# Altium schematic sheet BQ107-A5.SchDoc
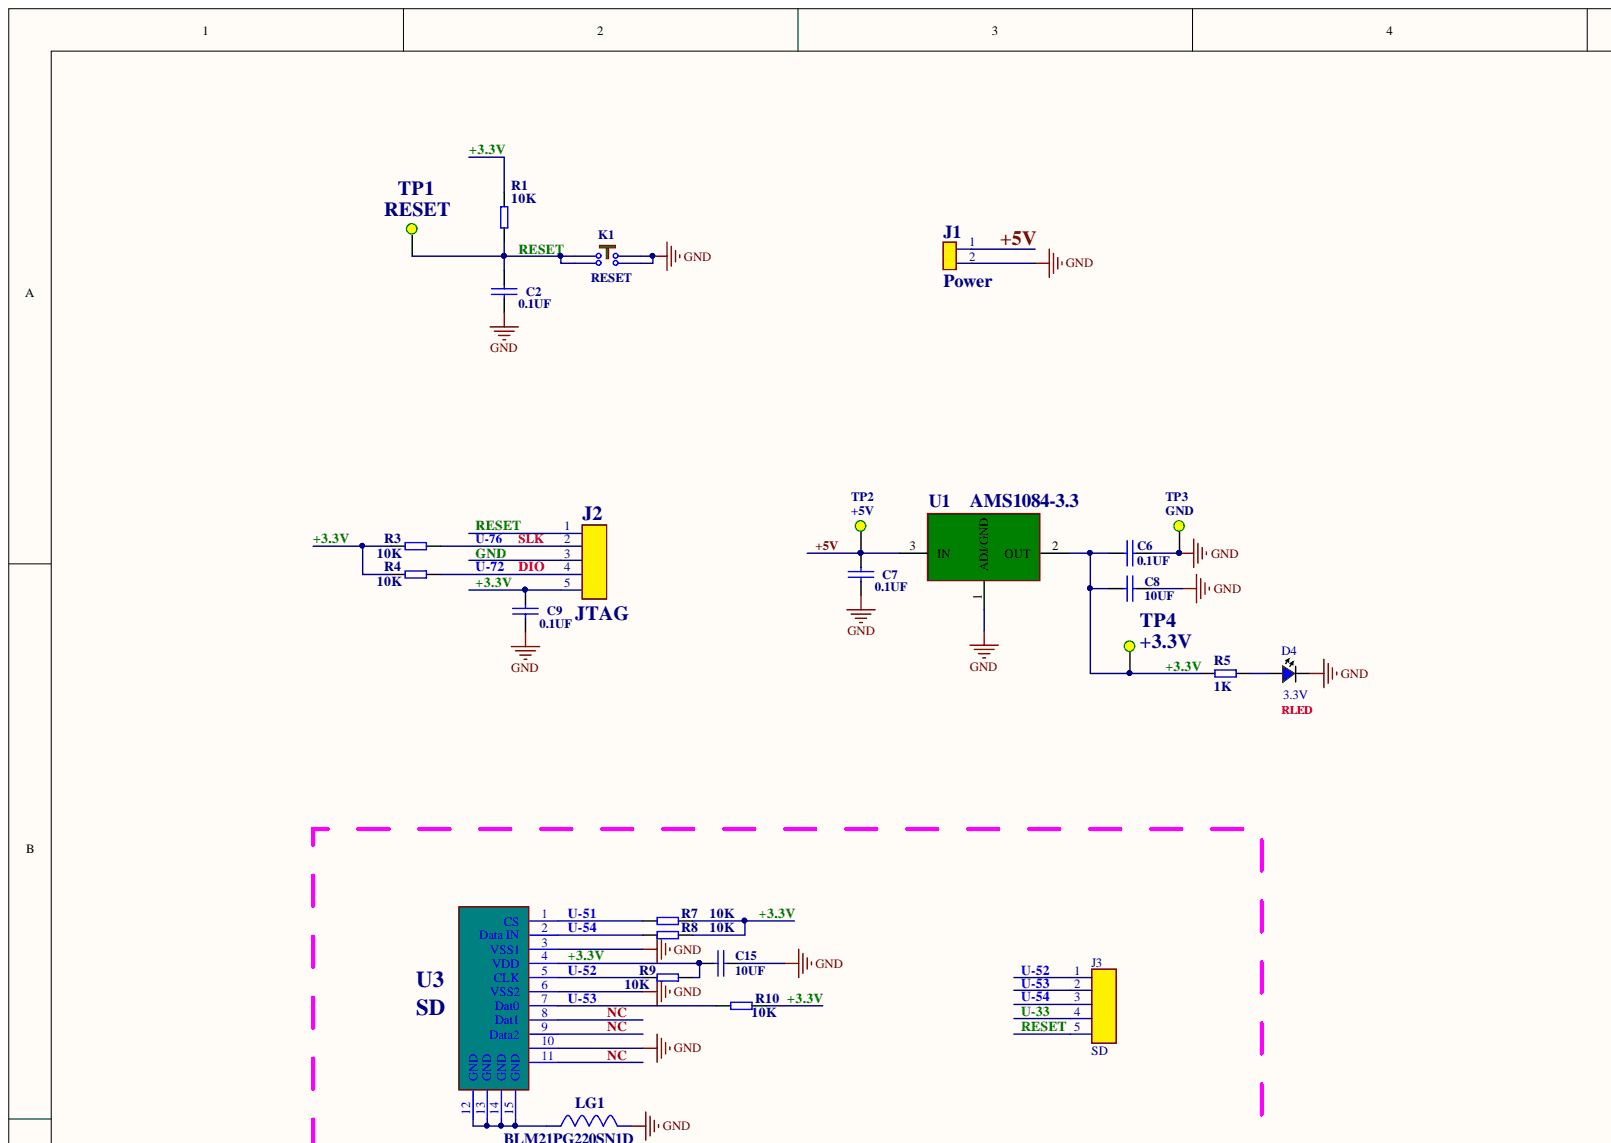
[diagram p0: BQ107-A5.SchDoc, top left]
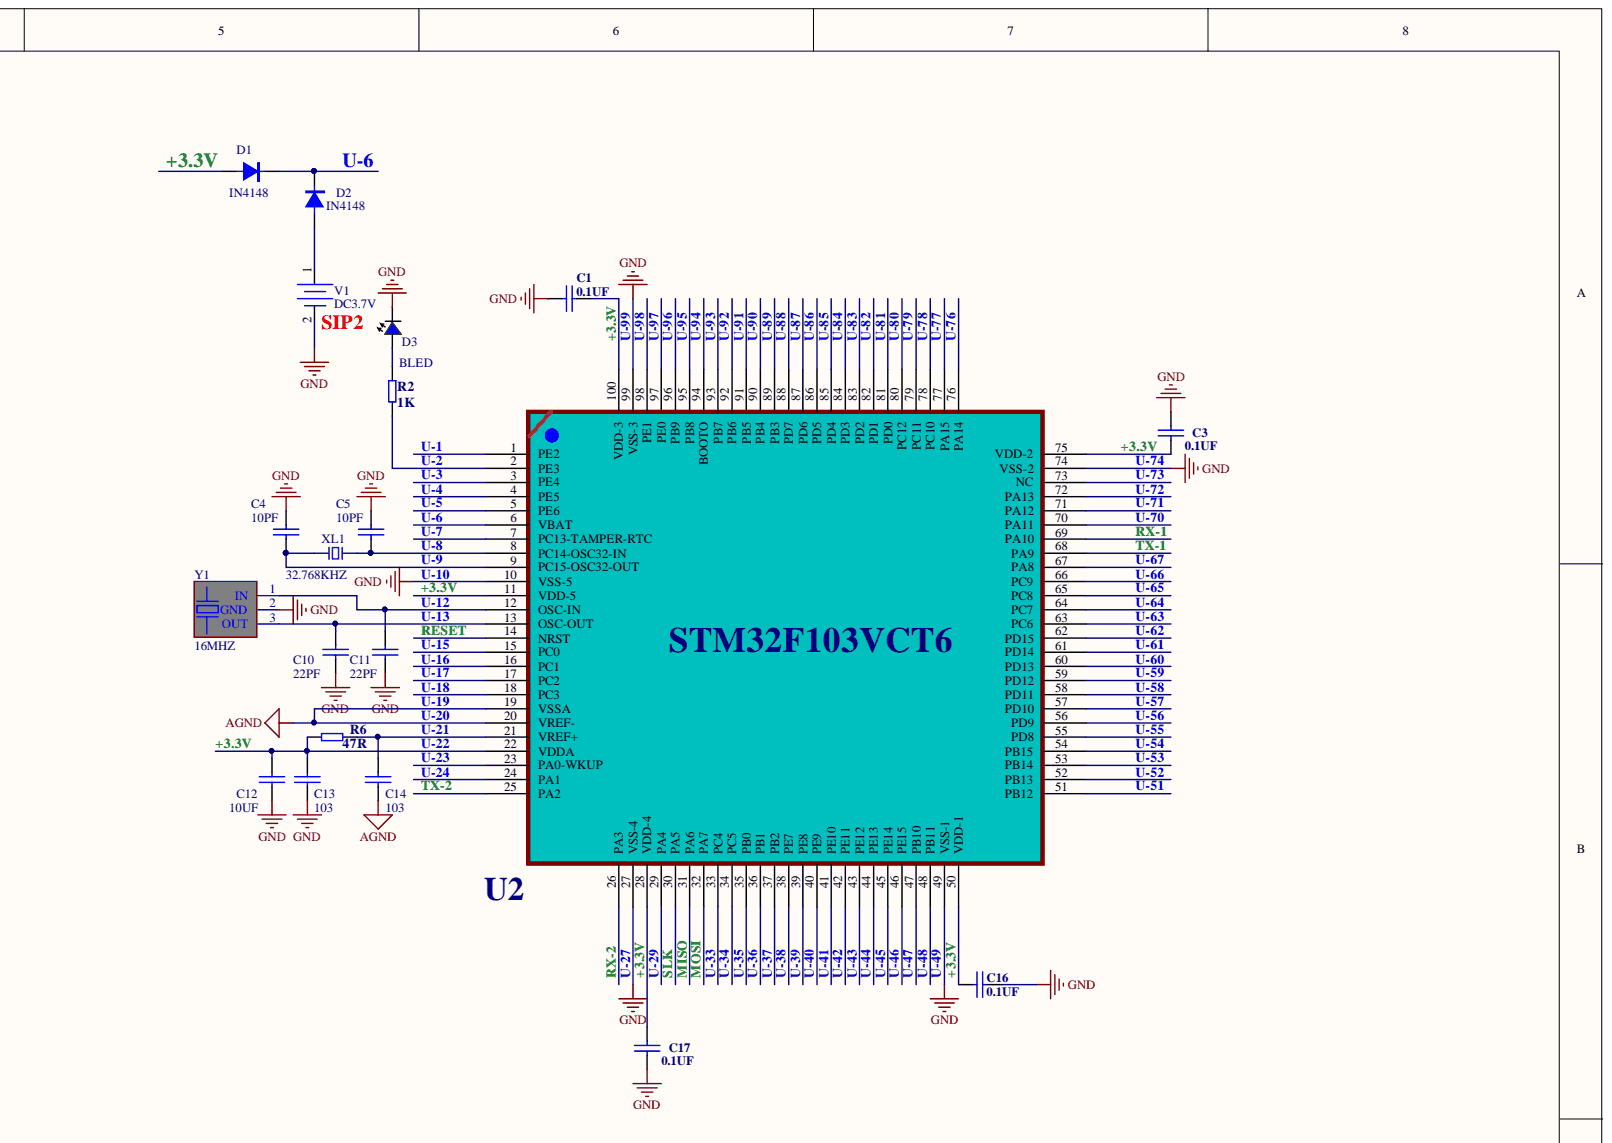
[diagram p1: BQ107-A5.SchDoc, top right]
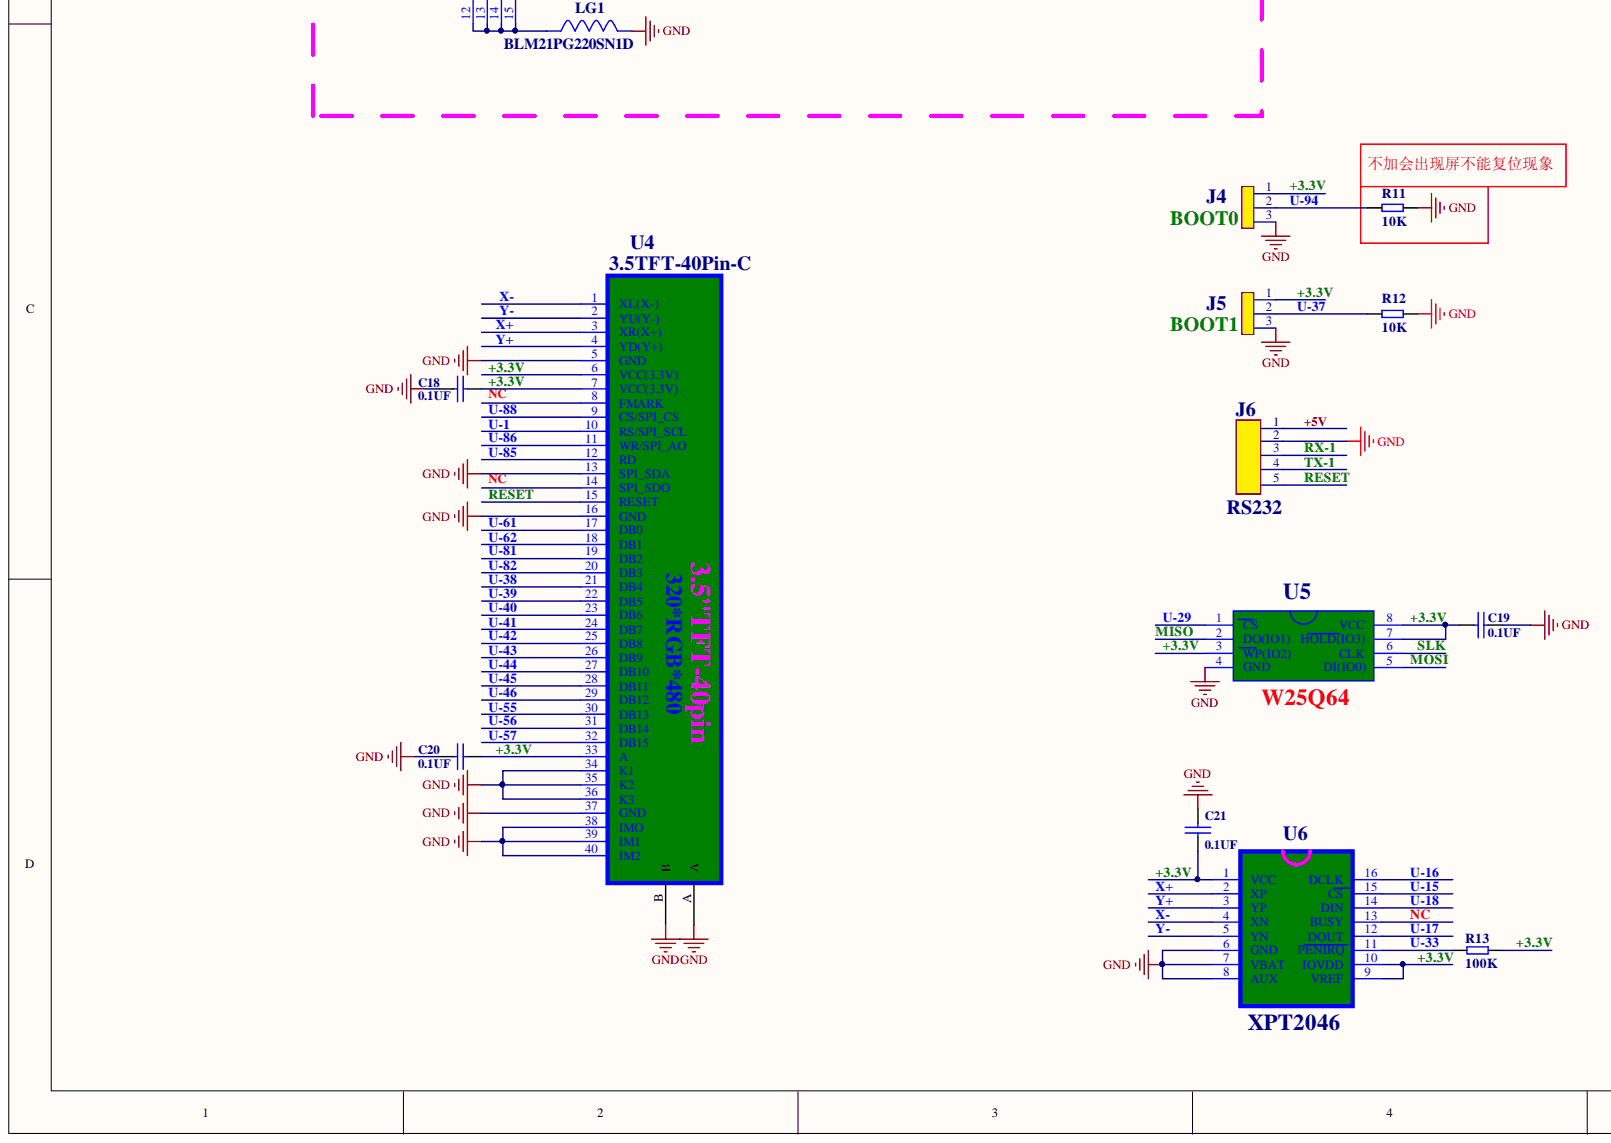
[diagram p2: BQ107-A5.SchDoc, bottom left]
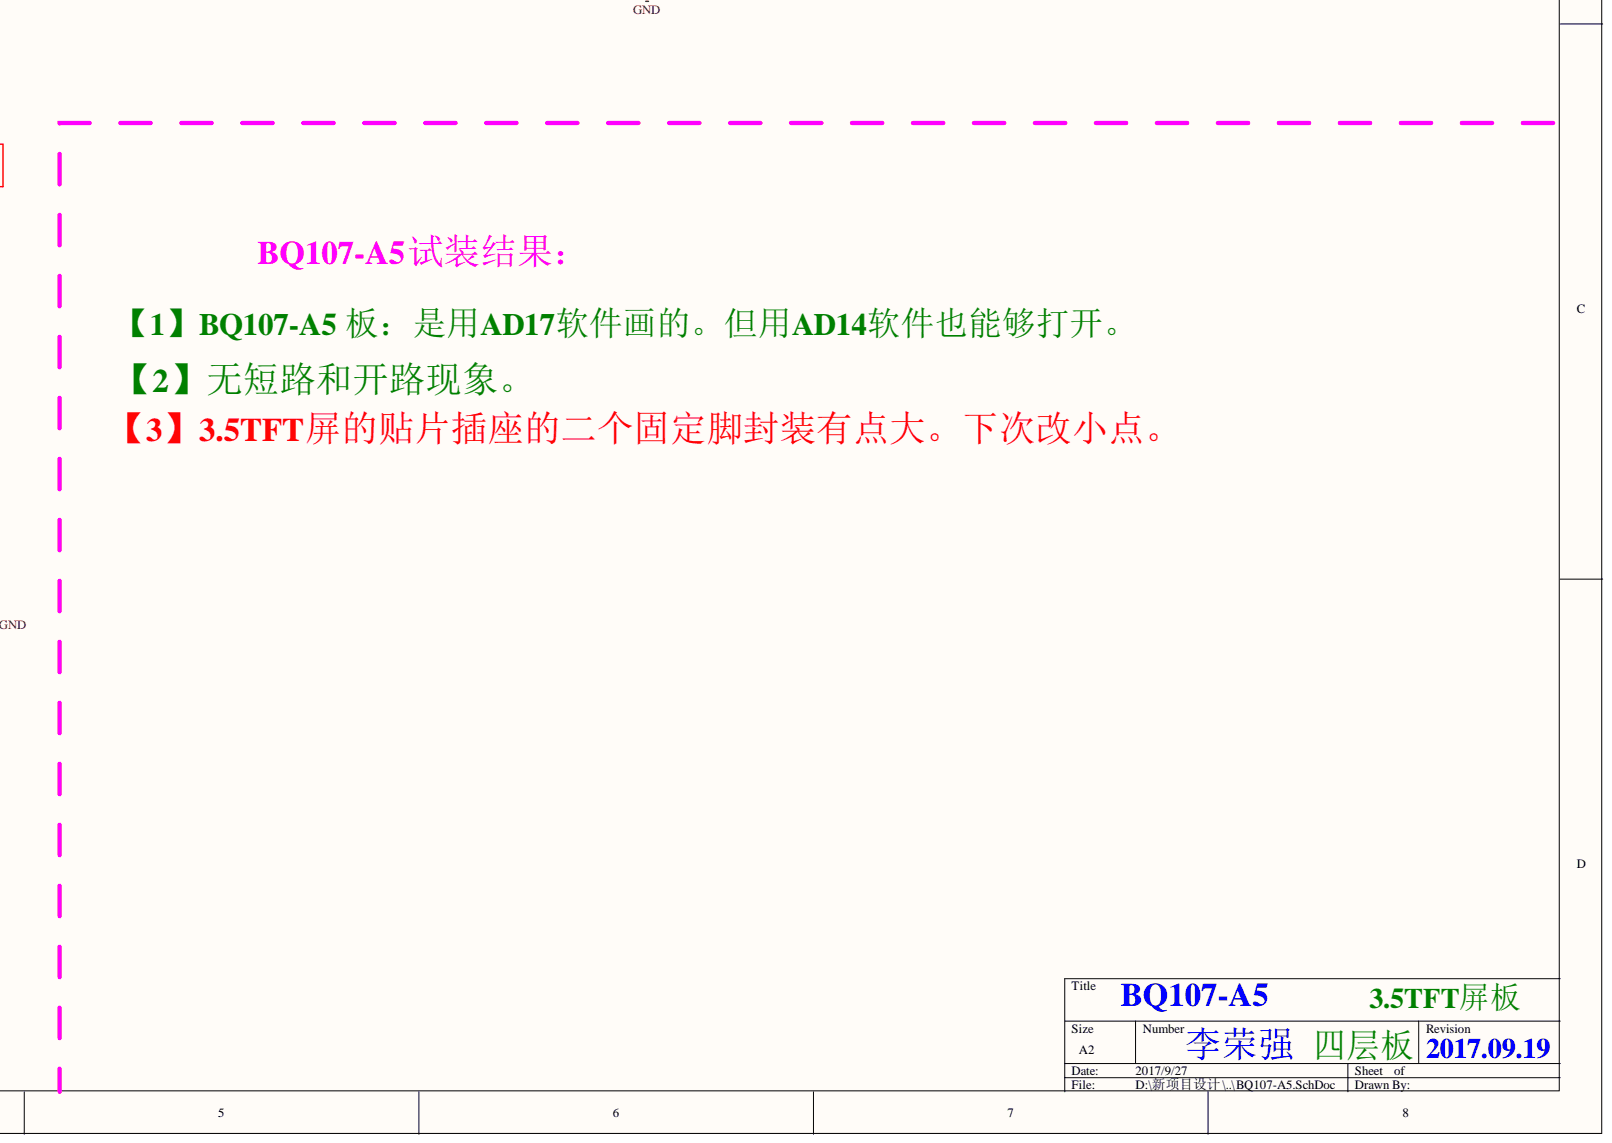
[diagram p3: BQ107-A5.SchDoc, bottom right]

## Parts
C1  0.1UF  [0603N]
C2  0.1UF  [0603N]
C3  0.1UF  [0603N]
C4  10PF  [0603N]
C5  10PF  [0603N]
C6  0.1UF  [0603N]
C7  0.1UF  [0603N]
C8  10UF  [0805N]
C9  0.1UF  [0603N]
C10  22PF  [0603N]
C11  22PF  [0603N]
C12  10UF  [0805N]
C13  103  [0603N]
C14  103  [0603N]
C15  10UF  [0805N]
C16  0.1UF  [0603N]
C17  0.1UF  [0603N]
C18  0.1UF  [0603N]
C19  0.1UF  [0603N]
C20  0.1UF  [0603N]
C21  0.1UF  [0603N]
D1  IN4148  [LL34]
D2  IN4148  [LL34]
D3  BLED  [LED-0805]
D4  3.3V  [LED-0805]
J1  Power  [2.54-2P]
J2  JTAG  [SIP5]
J3  SD  [SIP5]
J4  BOOT0  [SIP3]
J5  BOOT1  [SIP3]
J6  RS232  [2.54-5P]
K1  RESET  [K-4.5*4.5]
LG1  BLM21PG220SN1D  [0805N]
R1  10K  [0603N]
R2  1K  [0603N]
R3  10K  [0603N]
R4  10K  [0603N]
R5  1K  [0603N]
R6  47R  [0603N]
R7  10K  [0603N]
R8  10K  [0603N]
R9  10K  [0603N]
R10  10K  [0603N]
R11  10K  [0603N]
R12  10K  [0603N]
R13  100K  [0603N]
TP1  RESET  [TEST]
TP2  +5V  [TEST]
TP3  GND  [TEST]
TP4  +3.3V  [TEST]
U1  AMS1084-3.3  [TO-252-C]
U2  STM32F103VCT6  [TQFP-100-B]
U3  SD  [SD]
U4  3.5TFT-40Pin-C  [3.5TFT-40Pin-C]
U5  W25Q64  [SOP-8-L]
U6  XPT2046  [TSSOP-16 -B]
V1  DC3.7V  [BAT1]
XL1  32.768KHZ  [3215]
Y1  16MHZ  [SMD-3]

## Nets
+3.3V: C1.1 C3.2 C6.1 C8.1 C9.1 C12.1 C13.1 C15.1 C16.1 C17.1 C18.2 C19.2 C20.2 C21.2 D1.1 J2.5 J4.1 J5.1 R1.2 R3.2 R4.2 R5.2 R6.1 R7.1 R8.1 R9.1 R10.1 R13.1 TP4.1 U1.2 U2.11(VDD-5) U2.22(VDDA) U2.28(VDD-4) U2.50(VDD-1) U2.75(VDD-2) U2.100(VDD-3) U3.4(VDD) U4.6(VCC(3.3V)) U4.7(VCC(3.3V)) U4.33(A) U5.3(WP(IO2)) U5.7(HOLD(IO3)) U5.8(VCC) U6.1(VCC) U6.9(VREF) U6.10(IOVDD)
+5V: C7.1 J1.1 J6.1 TP2.1 U1.3
GND: C1.2 C2.2 C3.1 C4.1 C5.1 C6.2 C7.2 C8.2 C9.2 C10.2 C11.2 C12.2 C13.2 C15.2 C16.2 C17.2 C18.1 C19.1 C20.1 C21.1 D3.2 D4.2 J1.2 J2.3 J4.3 J5.3 J6.2 K1.2 K1.4 LG1.2 R11.1 R12.1 TP3.1 U1.1 U2.10(VSS-5) U2.27(VSS-4) U2.49(VSS-1) U2.74(VSS-2) U2.99(VSS-3) U3.3(VSS1) U3.6(VSS2) U3.10 U4.5(GND) U4.13(SPI_SDA) U4.16(GND) U4.34(K1) U4.35(K2) U4.36(K3) U4.37(GND) U4.38(IMO) U4.39(IM1) U4.40(IM2) U4.A U4.B U5.4(GND) U6.6(GND) U6.7(VBAT) U6.8(AUX) V1.2 Y1.2
MISO: U2.31(PA6) U5.2(DO(IO1))
MOSI: U2.32(PA7) U5.5(DI(IO0))
RESET: C2.1 J2.1 J3.5 J6.5 K1.1 K1.3 R1.1 TP1.1 U2.14(NRST) U4.15(RESET)
RX-1: J6.3 U2.69(PA10)
RX-2: U2.26(PA3)
SLK: U2.30(PA5) U5.6(CLK)
TX-1: J6.4 U2.68(PA9)
TX-2: U2.25(PA2)
U-1: U2.1(PE2) U4.10(RS/SPI_SCL)
U-2: R2.2 U2.2(PE3)
U-3: U2.3(PE4)
U-4: U2.4(PE5)
U-5: U2.5(PE6)
U-6: D1.2 D2.2 U2.6(VBAT)
U-7: U2.7(PC13-TAMPER-RTC)
U-8: C5.2 U2.8(PC14-OSC32-IN) XL1.2
U-9: C4.2 U2.9(PC15-OSC32-OUT) XL1.1
U-12: C11.1 U2.12(OSC-IN) Y1.1
U-13: C10.1 U2.13(OSC-OUT) Y1.3
U-15: U2.15(PC0) U6.15(CS)
U-16: U2.16(PC1) U6.16(DCLK)
U-17: U2.17(PC2) U6.12(DOUT)
U-18: U2.18(PC3) U6.14(DIN)
U-19: C14.2 U2.19(VSSA) U2.20(VREF-)
U-21: C14.1 R6.2 U2.21(VREF+)
U-23: U2.23(PA0-WKUP)
U-24: U2.24(PA1)
U-29: U2.29(PA4) U5.1(CS)
U-33: J3.4 R13.2 U2.33(PC4) U6.11(PENIRQ)
U-34: U2.34(PC5)
U-35: U2.35(PB0)
U-36: U2.36(PB1)
U-37: J5.2 R12.2 U2.37(PB2)
U-38: U2.38(PE7) U4.21(DB4)
U-39: U2.39(PE8) U4.22(DB5)
U-40: U2.40(PE9) U4.23(DB6)
U-41: U2.41(PE10) U4.24(DB7)
U-42: U2.42(PE11) U4.25(DB8)
U-43: U2.43(PE12) U4.26(DB9)
U-44: U2.44(PE13) U4.27(DB10)
U-45: U2.45(PE14) U4.28(DB11)
U-46: U2.46(PE15) U4.29(DB12)
U-47: U2.47(PB10)
U-48: U2.48(PB11)
U-51: R7.2 U2.51(PB12) U3.1(CS)
U-52: J3.1 R9.2 U2.52(PB13) U3.5(CLK)
U-53: J3.2 R10.2 U2.53(PB14) U3.7(Dat0)
U-54: J3.3 R8.2 U2.54(PB15) U3.2(Data_IN)
U-55: U2.55(PD8) U4.30(DB13)
U-56: U2.56(PD9) U4.31(DB14)
U-57: U2.57(PD10) U4.32(DB15)
U-58: U2.58(PD11)
U-59: U2.59(PD12)
U-60: U2.60(PD13)
U-61: U2.61(PD14) U4.17(DB0)
U-62: U2.62(PD15) U4.18(DB1)
U-63: U2.63(PC6)
U-64: U2.64(PC7)
U-65: U2.65(PC8)
U-66: U2.66(PC9)
U-67: U2.67(PA8)
U-70: U2.70(PA11)
U-71: U2.71(PA12)
U-72: J2.4 R4.1 U2.72(PA13)
U-73: U2.73(NC)
U-76: J2.2 R3.1 U2.76(PA14)
U-77: U2.77(PA15)
U-78: U2.78(PC10)
U-79: U2.79(PC11)
U-80: U2.80(PC12)
U-81: U2.81(PD0) U4.19(DB2)
U-82: U2.82(PD1) U4.20(DB3)
U-83: U2.83(PD2)
U-84: U2.84(PD3)
U-85: U2.85(PD4) U4.12(RD)
U-86: U2.86(PD5) U4.11(WR/SPI_AO)
U-87: U2.87(PD6)
U-88: U2.88(PD7) U4.9(CS/SPI_CS)
U-89: U2.89(PB3)
U-90: U2.90(PB4)
U-91: U2.91(PB5)
U-92: U2.92(PB6)
U-93: U2.93(PB7)
U-94: J4.2 R11.2 U2.94(BOOTO)
U-95: U2.95(PB8)
U-96: U2.96(PB9)
U-97: U2.97(PE0)
U-98: U2.98(PE1)
X+: U4.3(XR(X+)) U6.2(XP)
X-: U4.1(XL(X-)) U6.4(XN)
Y+: U4.4(YD(Y+)) U6.3(YP)
Y-: U4.2(YU(Y-)) U6.5(YN)
NetD2_1: D2.1 V1.1
NetD3_1: D3.1 R2.1
NetD4_1: D4.1 R5.1
NetLG1_1: LG1.1 U3.12(GND) U3.13(GND) U3.14(GND) U3.15(GND)

Not connected: U3 8(Dat1) 9(Data2) 11; U4 8(FMARK) 14(SPI_SDO); U6 13(BUSY)

## Library data of the parts
C1-C21 = CAP: Component Kind: Standard; Library Name: liroqi.SchLib
D1 D2 = DIODE: Component Kind: Standard; Library Name: liroqi.SchLib
D3 D4 = LED: Component Kind: Standard; Library Name: liroqi.SchLib
J1 = SIP2: Component Kind: Standard; Library Name: liroqi.SchLib
J2 J3 J6 = SIP5: Component Kind: Standard; Library Name: liroqi.SchLib
J4 J5 = SIP3: Component Kind: Standard; Library Name: liroqi.SchLib
K1 = K5: Component Kind: Standard; Library Name: liroqi.SchLib
LG1 = L: Component Kind: Standard; Library Name: liroqi.SchLib
R1-R13 = RES: Component Kind: Standard; Library Name: liroqi.SchLib
TP1-TP4 = TP: Component Kind: Standard; Library Name: liroqi.SchLib
U1 = LM1084: Component Kind: Standard; Library Name: liroqi-2.SchLib
V1 = BATT: Component Kind: Standard; Library Name: liroqi.SchLib
XL1 = XTAL1: Component Kind: Standard; Library Name: liroqi.SchLib
Y1 = SMD-3: Component Kind: Standard; Library Name: liroqi.SchLib
U2 = STM32F103VCT6: Component Kind: Standard; Library Name: liroqi.SchLib
U3 = SD: Component Kind: Standard; Library Name: liroqi.SchLib
U4 = 3.5TFT-40pin-C: Component Kind: Standard; Library Name: liroqi.SchLib
U5 = W25Q64: Component Kind: Standard; Library Name: liroqi.SchLib
U6 = XPT2046: Component Kind: Standard; Library Name: liroqi.SchLib
